# Altium schematic sheet 7_DDR3.SchDoc(7_DDR3)
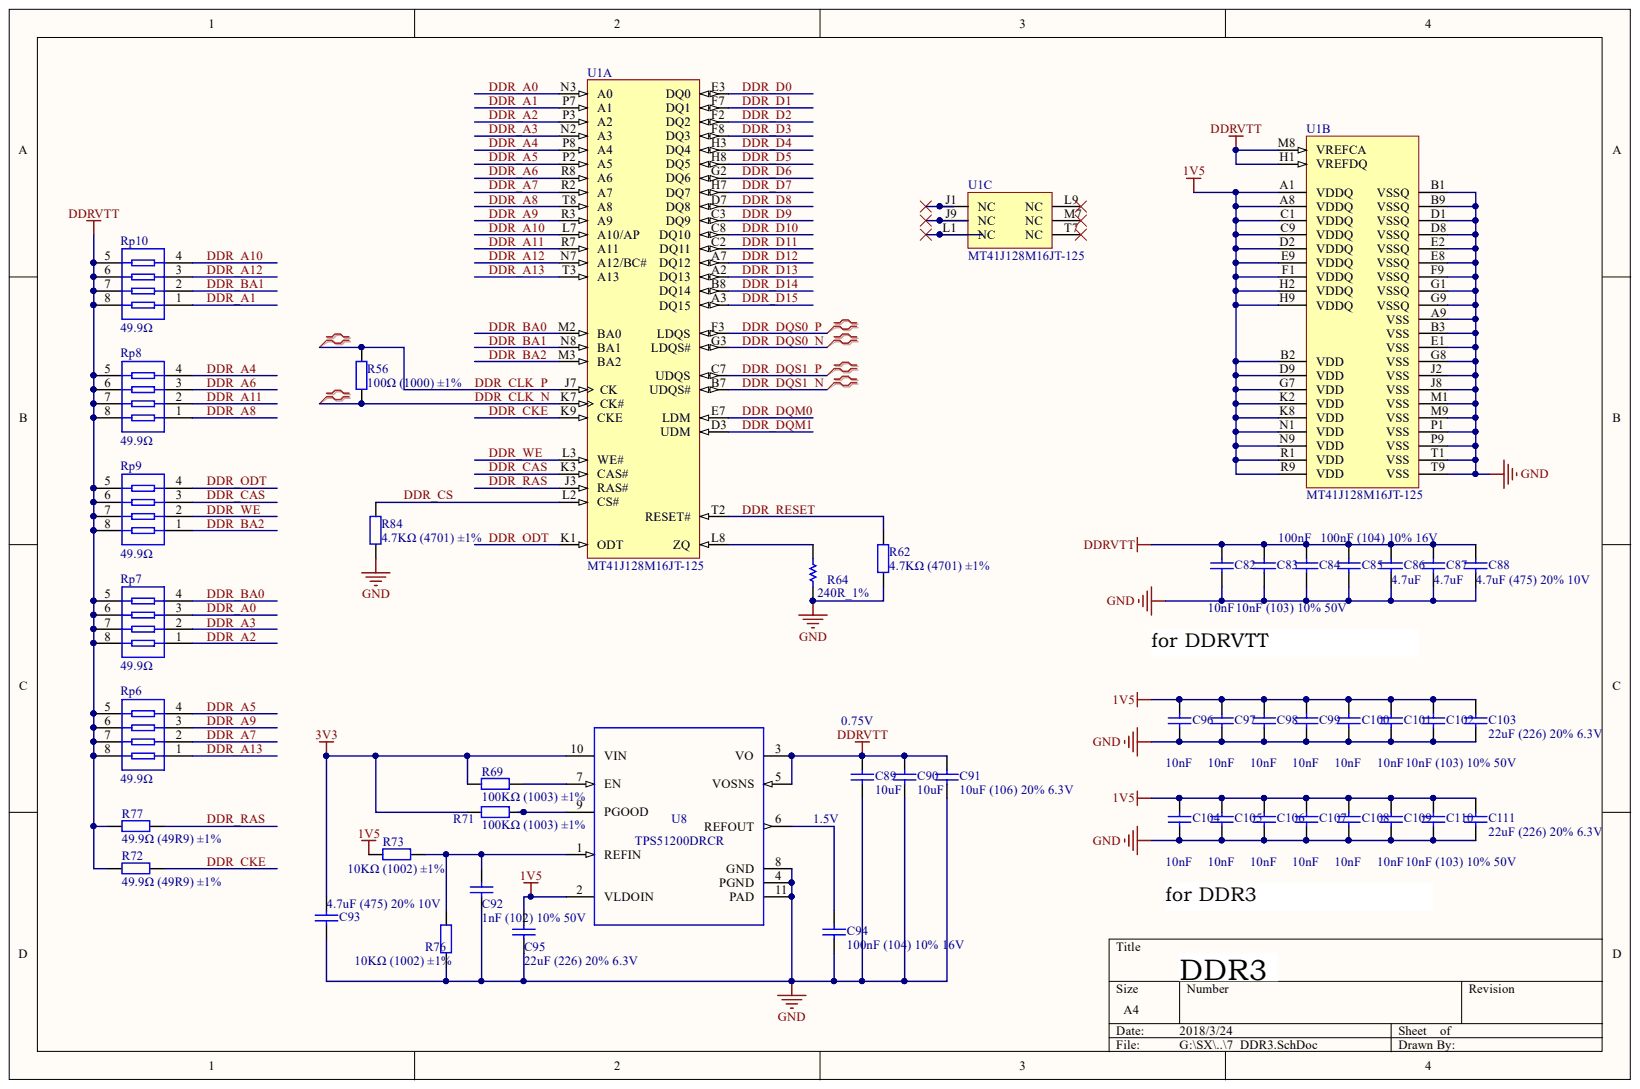
[diagram p0: 7_DDR3.SchDoc]

## Parts
C82  10nF  [0402_C]
C83  10nF (103) 10% 50V  [0402_C]
C84  100nF  [0402_C]
C85  100nF (104) 10% 16V  [0402_C]
C86  4.7uF  [0402_C]
C87  4.7uF  [0402_C]
C88  4.7uF (475) 20% 10V  [0402_C]
C89  10uF  [0402_C]
C90  10uF  [0402_C]
C91  10uF (106) 20% 6.3V  [0402_C]
C92  1nF (102) 10% 50V  [0402_C]
C93  4.7uF (475) 20% 10V  [0402_C]
C94  100nF (104) 10% 16V  [0402_C]
C95  22uF (226) 20% 6.3V  [0603_C]
C96  10nF  [0402_C]
C97  10nF  [0402_C]
C98  10nF  [0402_C]
C99  10nF  [0402_C]
C100  10nF  [0402_C]
C101  10nF  [0402_C]
C102  10nF (103) 10% 50V  [0402_C]
C103  22uF (226) 20% 6.3V  [0603_C]
C104  10nF  [0402_C]
C105  10nF  [0402_C]
C106  10nF  [0402_C]
C107  10nF  [0402_C]
C108  10nF  [0402_C]
C109  10nF  [0402_C]
C110  10nF (103) 10% 50V  [0402_C]
C111  22uF (226) 20% 6.3V  [0603_C]
R56  100Ω (1000) ±1%  [0402_R]
R62  4.7KΩ (4701) ±1%  [0402_R]
R64  value 240R_1%  [0402_R]  (hidden comment: Res1)
R69  100KΩ (1003) ±1%  [0402_R]
R71  100KΩ (1003) ±1%  [0402_R]
R72  49.9Ω (49R9) ±1%  [0402_R]
R73  10KΩ (1002) ±1%  [0402_R]
R76  10KΩ (1002) ±1%  [0402_R]
R77  49.9Ω (49R9) ±1%  [0402_R]
R84  4.7KΩ (4701) ±1%  [0402_R]
Rp6  49.9Ω  [0402_Rx4]
Rp7  49.9Ω  [0402_Rx4]
Rp8  49.9Ω  [0402_Rx4]
Rp9  49.9Ω  [0402_Rx4]
Rp10  49.9Ω  [0402_Rx4]
U1  MT41J128M16JT-125  [MICT-JT-96]  (parts A,B,C)
U8  TPS51200DRCR  [DRC_SON-10]

## Nets
1V5: C95.2 C96.2 C97.2 C98.2 C99.2 C100.2 C101.2 C102.2 C103.2 C104.2 C105.2 C106.2 C107.2 C108.2 C109.2 C110.2 C111.2 R73.1 U1.A1(VDDQ) U1.A8(VDDQ) U1.B2(VDD) U1.C1(VDDQ) U1.C9(VDDQ) U1.D2(VDDQ) U1.D9(VDD) U1.E9(VDDQ) U1.F1(VDDQ) U1.G7(VDD) U1.H2(VDDQ) U1.H9(VDDQ) U1.K2(VDD) U1.K8(VDD) U1.N1(VDD) U1.N9(VDD) U1.R1(VDD) U1.R9(VDD) U8.2(VLDOIN)
3V3: C93.2 R69.1 R71.1 U8.10(VIN)
DDRVTT: C82.2 C83.2 C84.2 C85.2 C86.2 C87.2 C88.2 C89.2 C90.2 C91.2 R72.1 R77.1 Rp6.5 Rp6.6 Rp6.7 Rp6.8 Rp7.5 Rp7.6 Rp7.7 Rp7.8 Rp8.5 Rp8.6 Rp8.7 Rp8.8 Rp9.5 Rp9.6 Rp9.7 Rp9.8 Rp10.5 Rp10.6 Rp10.7 Rp10.8 U1.H1(VREFDQ) U1.M8(VREFCA) U8.3(VO) U8.5(VOSNS)
GND: C82.1 C83.1 C84.1 C85.1 C86.1 C87.1 C88.1 C89.1 C90.1 C91.1 C92.1 C93.1 C94.1 C95.1 C96.1 C97.1 C98.1 C99.1 C100.1 C101.1 C102.1 C103.1 C104.1 C105.1 C106.1 C107.1 C108.1 C109.1 C110.1 C111.1 R62.1 R64.1 R76.2 R84.1 U1.A9(VSS) U1.B1(VSSQ) U1.B3(VSS) U1.B9(VSSQ) U1.D1(VSSQ) U1.D8(VSSQ) U1.E1(VSS) U1.E2(VSSQ) U1.E8(VSSQ) U1.F9(VSSQ) U1.G1(VSSQ) U1.G8(VSS) U1.G9(VSSQ) U1.J2(VSS) U1.J8(VSS) U1.M1(VSS) U1.M9(VSS) U1.P1(VSS) U1.P9(VSS) U1.T1(VSS) U1.T9(VSS) U8.4(PGND) U8.8(GND) U8.11(PAD)
DDR_A0: Rp7.3 U1.N3(A0)
DDR_A1: Rp10.1 U1.P7(A1)
DDR_A2: Rp7.1 U1.P3(A2)
DDR_A3: Rp7.2 U1.N2(A3)
DDR_A4: Rp8.4 U1.P8(A4)
DDR_A5: Rp6.4 U1.P2(A5)
DDR_A6: Rp8.3 U1.R8(A6)
DDR_A7: Rp6.2 U1.R2(A7)
DDR_A8: Rp8.1 U1.T8(A8)
DDR_A9: Rp6.3 U1.R3(A9)
DDR_A10: Rp10.4 U1.L7(A10/AP)
DDR_A11: Rp8.2 U1.R7(A11)
DDR_A12: Rp10.3 U1.N7(A12/BC#)
DDR_A13: Rp6.1 U1.T3(A13)
DDR_BA0: Rp7.4 U1.M2(BA0)
DDR_BA1: Rp10.2 U1.N8(BA1)
DDR_BA2: Rp9.1 U1.M3(BA2)
DDR_CAS: Rp9.3 U1.K3(CAS#)
DDR_CKE: R72.2 U1.K9(CKE)
DDR_CLK_N: R56.1 U1.K7(CK#)
DDR_CLK_P: R56.2 U1.J7(CK)
DDR_CS: R84.2 U1.L2(CS#)
DDR_D0: U1.E3(DQ0)
DDR_D1: U1.F7(DQ1)
DDR_D2: U1.F2(DQ2)
DDR_D3: U1.F8(DQ3)
DDR_D4: U1.H3(DQ4)
DDR_D5: U1.H8(DQ5)
DDR_D6: U1.G2(DQ6)
DDR_D7: U1.H7(DQ7)
DDR_D8: U1.D7(DQ8)
DDR_D9: U1.C3(DQ9)
DDR_D10: U1.C8(DQ10)
DDR_D11: U1.C2(DQ11)
DDR_D12: U1.A7(DQ12)
DDR_D13: U1.A2(DQ13)
DDR_D14: U1.B8(DQ14)
DDR_D15: U1.A3(DQ15)
DDR_DQM0: U1.E7(LDM)
DDR_DQM1: U1.D3(UDM)
DDR_DQS0_N: U1.G3(LDQS#)
DDR_DQS0_P: U1.F3(LDQS)
DDR_DQS1_N: U1.B7(UDQS#)
DDR_DQS1_P: U1.C7(UDQS)
DDR_ODT: Rp9.4 U1.K1(ODT)
DDR_RAS: R77.2 U1.J3(RAS#)
DDR_RESET: R62.2 U1.T2(RESET#)
DDR_WE: Rp9.2 U1.L3(WE#)
NetC92_2: C92.2 R73.2 R76.1 U8.1(REFIN)
NetC94_2: C94.2 U8.6(REFOUT)
NetR64_2: R64.2 U1.L8(ZQ)
NetR69_2: R69.2 U8.7(EN)
NetR71_2: R71.2 U8.9(PGOOD)

Not connected: U1 J1(NC) J9(NC) L1(NC) L9(NC) M7(NC) T7(NC)

## Library data of the parts
C82 C83 C96-C102 C104-C110 = 0402 10nF (103) 10% 50V: 贴片电容; Component Kind: Standard; ComponentLink1Description: 供应商链接; ComponentLink1URL: http://www.szlcsc.com/product/details_15869.html; Library Name: Miscellaneous Devices LC.IntLib; Package: 0402; Supplier: LC; SuppliersPartNumber: C15195
C84 C85 C94 = 0402 100nF (104) 10% 16V: 贴片电容; Component Kind: Standard; ComponentLink1Description: 供应商链接; ComponentLink1URL: http://www.szlcsc.com/product/details_1877.html; Library Name: Miscellaneous Devices LC.IntLib; Package: 0402; Supplier: LC; SuppliersPartNumber: C1525
C86-C88 C93 = 0402 4.7uF (475) 20% 10V: 贴片电容; Component Kind: Standard; ComponentLink1Description: 供应商链接; ComponentLink1URL: http://www.szlcsc.com/product/details_24469.html; Library Name: Miscellaneous Devices LC.IntLib; Package: 0402; Supplier: LC; SuppliersPartNumber: C23733
C89-C91 = 0402 10uF (106) 20% 6.3V: 贴片电容; Component Kind: Standard; ComponentLink1Description: 供应商链接; ComponentLink1URL: http://www.szlcsc.com/product/details_16204.html; Library Name: Miscellaneous Devices LC.IntLib; Package: 0402; Supplier: LC; SuppliersPartNumber: C15525
C92 = 0402 1nF (102) 10% 50V: 贴片电容; Component Kind: Standard; ComponentLink1Description: 供应商链接; ComponentLink1URL: http://www.szlcsc.com/product/details_1875.html; Library Name: Miscellaneous Devices LC.IntLib; Package: 0402; Supplier: LC; SuppliersPartNumber: C1523
C95 C103 C111 = 0603 22uF (226) 20% 6.3V: 贴片电容; Component Kind: Standard; ComponentLink1Description: 供应商链接; ComponentLink1URL: http://www.szlcsc.com/product/details_60514.html; Library Name: Miscellaneous Devices LC.IntLib; Package: 0603; Supplier: LC; SuppliersPartNumber: C59461
R56 = 0402 100Ω (1000) 1%: 贴片电阻; Component Kind: Standard; ComponentLink1Description: 供应商链接; ComponentLink1URL: http://www.szlcsc.com/product/details_25819.html; Library Name: Miscellaneous Devices LC.IntLib; Package: 0402; Supplier: LC; SuppliersPartNumber: C25076
R62 R84 = 0402 4.7KΩ (4701) 1%: 贴片电阻; Component Kind: Standard; ComponentLink1Description: 供应商链接; ComponentLink1URL: http://www.szlcsc.com/product/details_26643.html; Library Name: Miscellaneous Devices LC.IntLib; Package: 0402; Supplier: LC; SuppliersPartNumber: C25900
R64 = Res1: Resistor; Component Kind: Standard; LatestRevisionDate: 17-Jul-2002; LatestRevisionNote: Re-released for DXP Platform.; Library Name: Miscellaneous Devices.IntLib; PackageDescription: Axial Device, Thru-Hole; 2 Leads; 0.3 in Pin Spacing; PackageReference: AXIAL-0.3; Published: 8-Jun-2000; Simulation: RESISTOR; Publisher: Altium Limited
R69 R71 = 0402 100KΩ (1003) 1%: 贴片电阻; Component Kind: Standard; ComponentLink1Description: 供应商链接; ComponentLink1URL: http://www.szlcsc.com/product/details_26484.html; Library Name: Miscellaneous Devices LC.IntLib; Package: 0402; Supplier: LC; SuppliersPartNumber: C25741
R72 R77 = 0402 49.9Ω (49R9) 1%: 贴片电阻; Component Kind: Standard; ComponentLink1Description: 供应商链接; ComponentLink1URL: http://www.szlcsc.com/product/details_25863.html; Library Name: Miscellaneous Devices LC.IntLib; Package: 0402; Supplier: LC; SuppliersPartNumber: C25120
R73 R76 = 0402 10KΩ (1002) 1%: 贴片电阻; Component Kind: Standard; ComponentLink1Description: 供应商链接; ComponentLink1URL: http://www.szlcsc.com/product/details_26487.html; Library Name: Miscellaneous Devices LC.IntLib; Package: 0402; Supplier: LC; SuppliersPartNumber: C25744
Rp6-Rp10 = 0402*4 10KΩ (103) 5%: 贴片排阻; Component Kind: Standard; ComponentLink1Description: 供应商链接; ComponentLink1URL: http://www.szlcsc.com/product/details_26468.html; Library Name: Miscellaneous Devices LC.IntLib; Package: 0402*4; Supplier: LC; SuppliersPartNumber: C25725
U1 = MT41J128M16JT-125: 2Gb DDR3-1600 SDRAM, 128Mb x 16, 1.25ns, 1.5V, 0 to 95 degC, 96-Ball FBGA, Pb-Free; Manufacturer: Micron; CL: CL = 11?; Clock Rate: 800 MHz?; Cycle Time: 1.25ns?; Data Rate: DDR3-1600?; Density: 2Gb?; Op. Temp.: 0C to +95C?; Voltage: 1.5V?; Component Kind: Standard; ComponentLink1Description: Manufacturer URL; ComponentLink1URL: http://www.micron.com/; ComponentLink2Description: Datasheet; ComponentLink2URL: http://www.micron.com/~/media/Documents/Products/Data%20Sheet/DRAM/2Gb_DDR3_SDRAM.pdf; ComponentLink3Description: Package Specification; ComponentLink3URL: http://www.micron.com/~/media/Documents/Products/Data%20Sheet/DRAM/2Gb_DDR3_SDRAM.pdf; DatasheetVersion: Rev. P; Depth: 128Mb?; Library Name: Micron Memory DDR3 SDRAM.IntLib; Mounting Technology: Surface Mount; Package: FBGA?; PackageDescription: 96-Ball Fine-Pitch Ball Grid Array, 14 x 8 mm, Pitch 0.8 mm; PackageReference: MICT-JT-96; PackageVersion: Rev.G; PartNumber: MT41J128M16JT-125; Pin Count: 96-ball?; RoHS: Yes?; Width: x16?
U8 = TPS51200DRCR: Manufacturer Name: Texas Instruments; Component Kind: Standard; Description: TPS51200DRCR; Library Name: TI WEBENCH; Manufacturer Part Number: TPS51200DRCR; PackageReference: TPS51200DRCR; Value: N/A
